# Altium schematic sheet EVB-NORA-B2_SCHEM_0003_USBhub_FTDI.SchDoc(EVB-NORA-B2_SCHEM_0003_USBhub_FTDI)
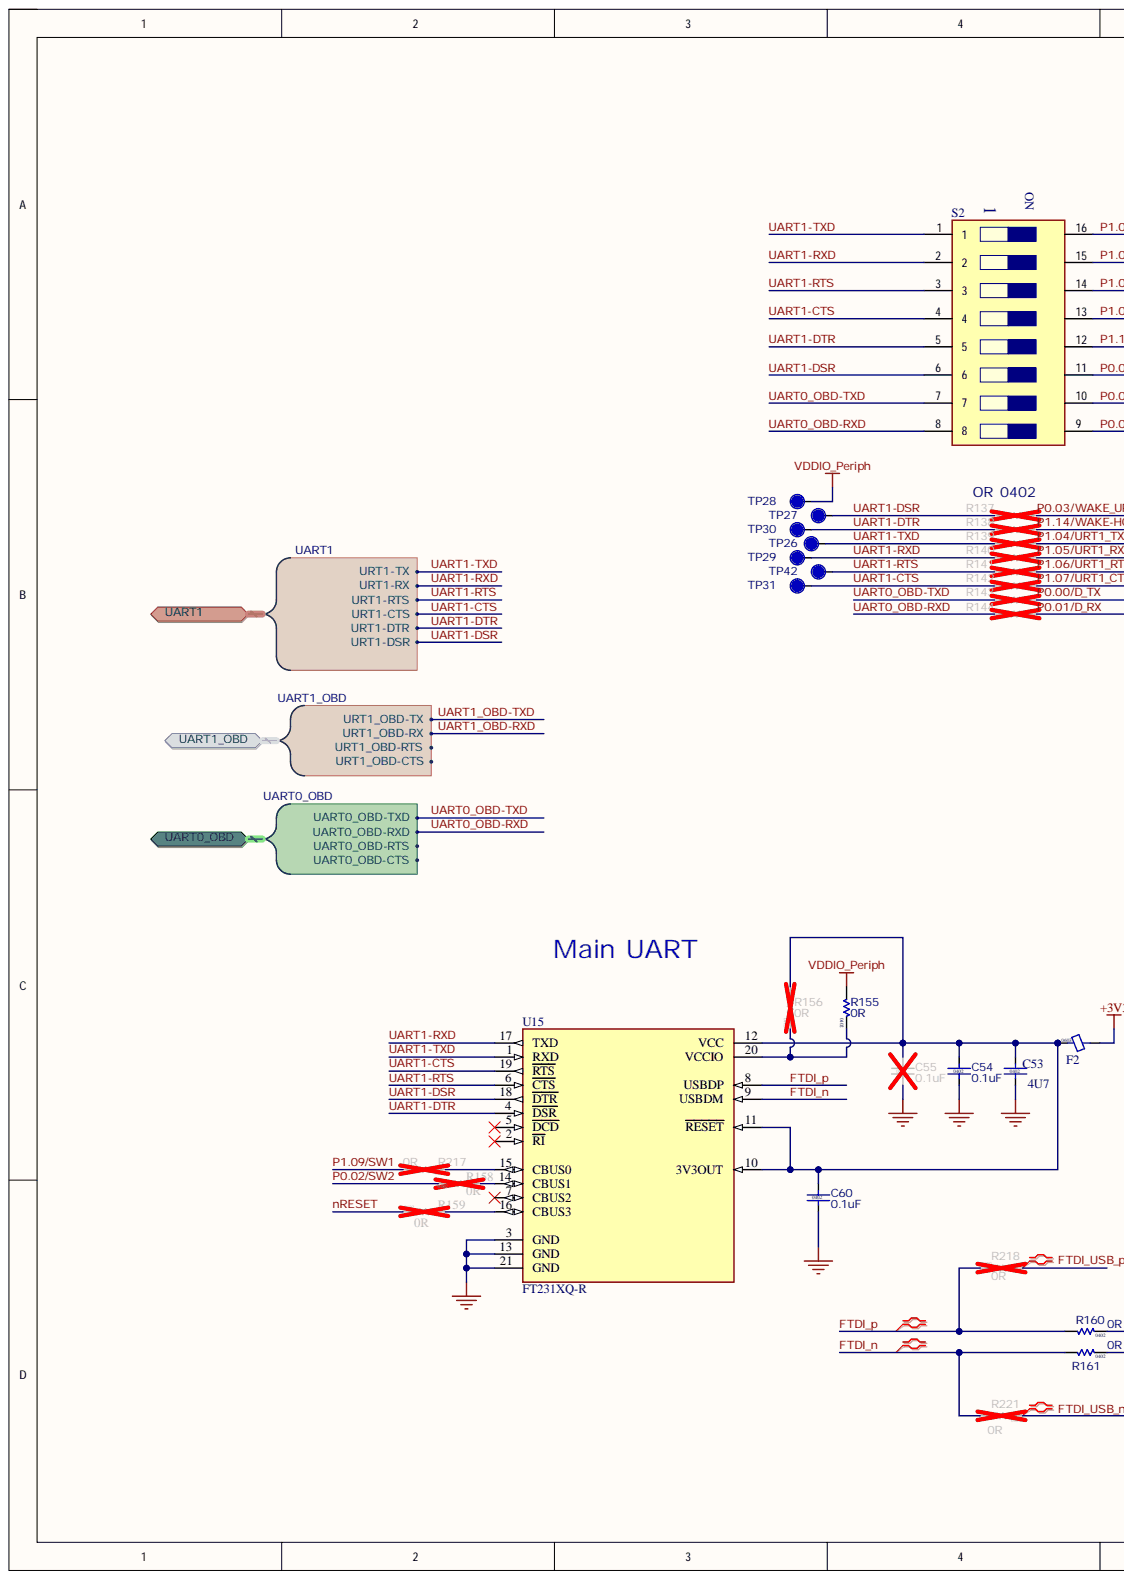
[diagram p0: EVB-NORA-B2_SCHEM_0003_USBhub_FTDI.SchDoc, left]
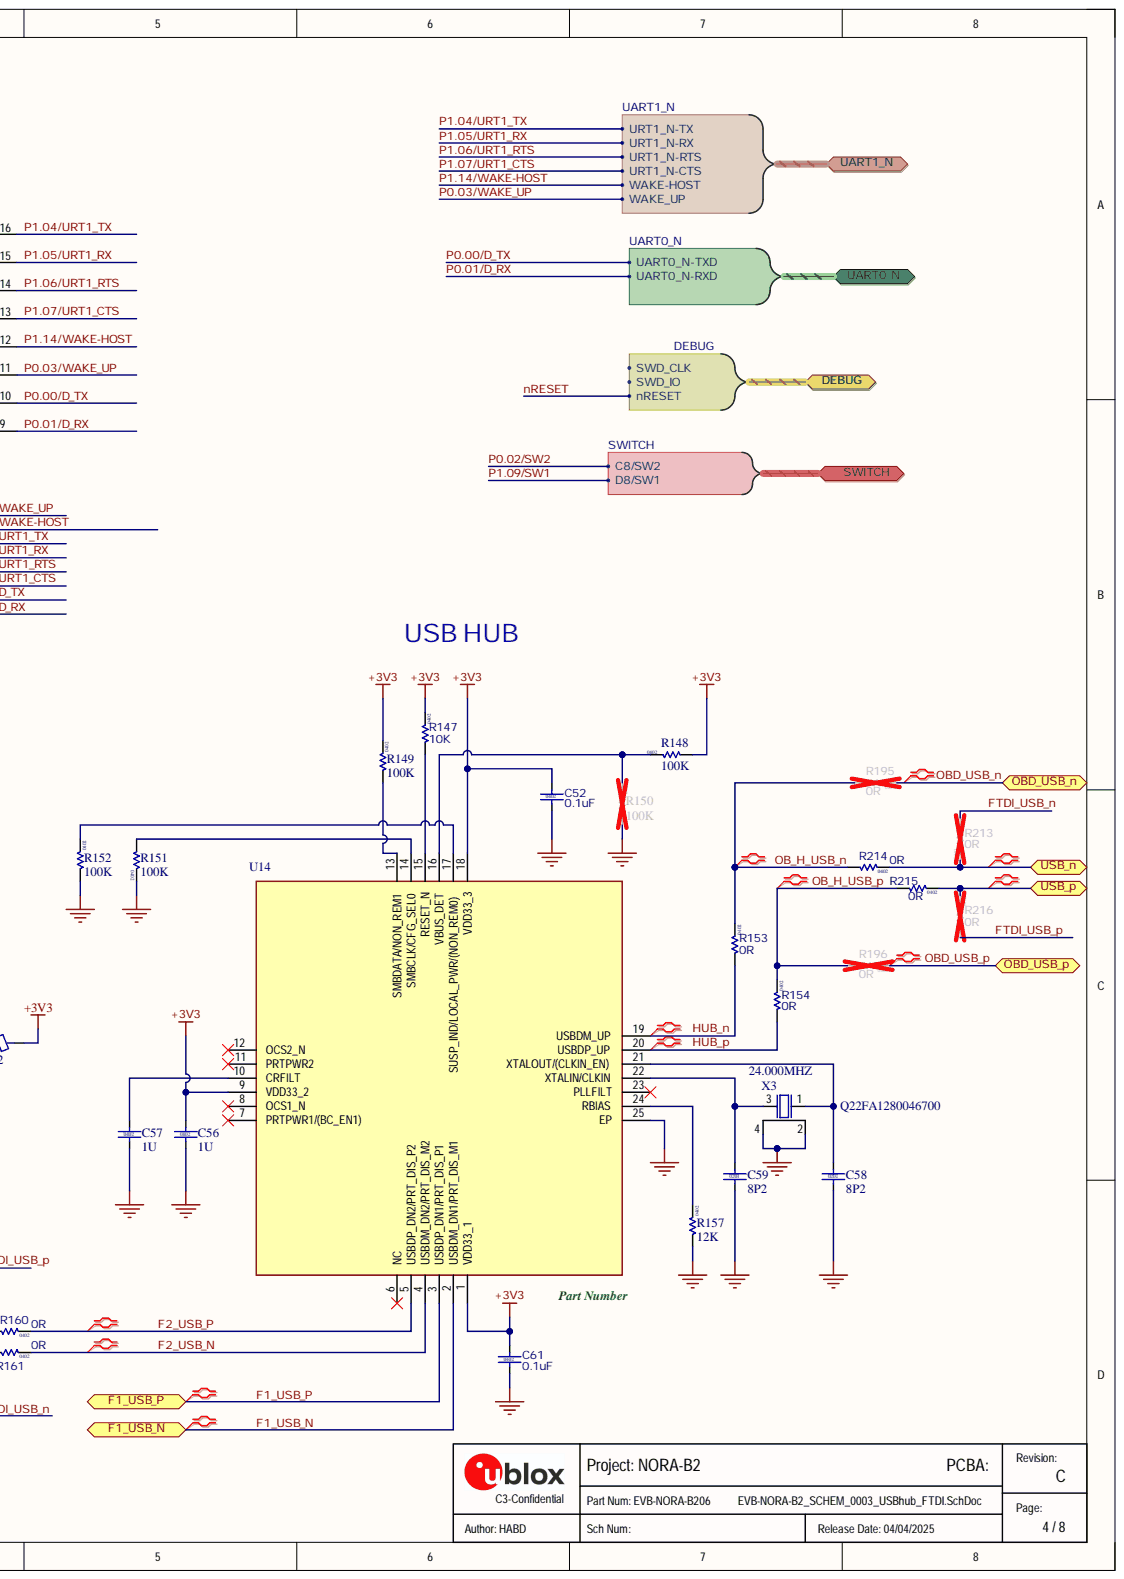
[diagram p1: EVB-NORA-B2_SCHEM_0003_USBhub_FTDI.SchDoc, right]

## Parts
C52  value 0.1uF  Size: 0402  [Cap 0402 HD]  (hidden comment: 120-00016)
C53  value 4U7  Size: 0402  [Cap 0402 HD]
C54  value 0.1uF  Size: 0402  [Cap 0402 HD]  (hidden comment: 120-000160.1uF)
C55  value 0.1uF  Size: 0402  [Cap 0402 HD]  (hidden comment: 120-000160.1uF)
C56  value 1U  Size: 0402  [Cap 0402 HD]  (hidden comment: UBXH01-0000248)
C57  value 1U  Size: 0402  [Cap 0402 HD]  (hidden comment: UBXH01-0000248)
C58  value 8P2  Size: 0201  [Cap 0201 HD]  (hidden comment: UBXH01-0013002)
C59  value 8P2  Size: 0201  [Cap 0201 HD]  (hidden comment: UBXH01-0013002)
C60  value 0.1uF  Size: 0402  [Cap 0402 HD]  (hidden comment: 120-000160.1uF)
C61  value 0.1uF  Size: 0402  [Cap 0402 HD]  (hidden comment: 120-00016)
F2  Size: 0603  [IND_0603_1750Lx950Wx1050H_600x800Pad_800G_FLX]
R137  Size: 0402  [RES 0402 HD]
R138  = "Part Number" + Value + DNP  [RES 0402 HD]
R139  = "Part Number" + Value + DNP  [RES 0402 HD]
R140  = "Part Number" + Value + DNP  [RES 0402 HD]
R141  = "Part Number" + Value + DNP  [RES 0402 HD]
R142  = "Part Number" + Value + DNP  [RES 0402 HD]
R143  = "Part Number" + Value + DNP  [RES 0402 HD]
R144  = "Part Number" + Value + DNP  [RES 0402 HD]
R147  value 10K  Size: 0402  [RES 0402 HD]  (hidden comment: UBXH18-0000065)
R148  value 100K  Size: 0402  [RES 0402 HD]  (hidden comment: UBXH18-0000005)
R149  value 100K  Size: 0402  [RES 0402 HD]  (hidden comment: UBXH18-0000005)
R150  value 100K  Size: 0402  [RES 0402 HD]
R151  value 100K  Size: 0402  [RES 0402 HD]  (hidden comment: UBXH18-0000005)
R152  value 100K  Size: 0402  [RES 0402 HD]  (hidden comment: UBXH18-0000005)
R153  value 0R  Size: 0402  [RES 0402 HD]
R154  value 0R  Size: 0402  [RES 0402 HD]
R155  value 0R  Size: 0402  [RES 0402 HD]
R156  value 0R  Size: 0402  [RES 0402 HD]
R157  value 12K  Size: 0402  [RES_0402_1100Lx600Wx400H_500x600Pad_400G_FLX]  (hidden comment: UBXH18-0000133)
R158  value 0R  Size: 0402  [RES_0402_1100Lx600Wx400H_500x600Pad_400G_FLX]
R159  value 0R  Size: 0402  [RES_0402_1100Lx600Wx400H_500x600Pad_400G_FLX]
R160  value 0R  Size: 0402  [RES 0402 HD]
R161  value 0R  Size: 0402  [RES 0402 HD]
R195  value 0R  Size: 0402  [RES 0402 HD]
R196  value 0R  Size: 0402  [RES 0402 HD]
R213  UBXH18-0003002  value 0R  Size: 0402  [RES 0402 HD]
R214  value 0R  Size: 0402  [RES 0402 HD]  (hidden comment: UBXH18-0003002)
R215  value 0R  Size: 0402  [RES 0402 HD]  (hidden comment: UBXH18-0003002)
R216  UBXH18-0003002  value 0R  Size: 0402  [RES 0402 HD]
R217  UBXH18-0003002  value 0R  Size: 0402  [RES 0402 HD]
R218  UBXH18-0003002  value 0R  Size: 0402  [RES 0402 HD]
R221  UBXH18-0003002  value 0R  Size: 0402  [RES 0402 HD]
S2  (no value)  [2188LPSTJ]
TP26  TP10R  [TP10R]  (value not drawn)  (no BOM)
TP27  TP10R  [TP10R]  (value not drawn)  (no BOM)
TP28  TP10R  [TP10R]  (value not drawn)  (no BOM)
TP29  TP10R  [TP10R]  (value not drawn)  (no BOM)
TP30  TP10R  [TP10R]  (value not drawn)  (no BOM)
TP31  TP10R  [TP10R]  (value not drawn)  (no BOM)
TP42  TP10R  [TP10R]  (value not drawn)  (no BOM)
U14  ="Part Number"  [QFN50P400X400X100-25N-D]
U15  value FT231XQ-R  Manufacturer P/N: FT231XQ-R  [QFN_5x5_FT231XQ]  (hidden comment: UBXH10-0000054)
X3  value 24.000MHZ  Manufacturer P/N: Q22FA1280046700  [XTAL_2016_HD]  (hidden comment: UBXH21-0000066)

## Ports
DEBUG, DEBUG.nRESET, DEBUG.SWD_CLK, DEBUG.SWD_IO, F1_USB_N, F1_USB_P, OBD_USB_n, OBD_USB_p, SWITCH, SWITCH.C8/SW2, SWITCH.D8/SW1, UART0_N, UART0_N.UART0_N-RXD, UART0_N.UART0_N-TXD, UART0_OBD, UART0_OBD.UART0_OBD-CTS, UART0_OBD.UART0_OBD-RTS, UART0_OBD.UART0_OBD-RXD, UART0_OBD.UART0_OBD-TXD, UART1, UART1.URT1-CTS, UART1.URT1-DSR, UART1.URT1-DTR, UART1.URT1-RTS, UART1.URT1-RX, UART1.URT1-TX, UART1_N, UART1_N.URT1_N-CTS, UART1_N.URT1_N-RTS, UART1_N.URT1_N-RX, UART1_N.URT1_N-TX, UART1_N.WAKE-HOST, UART1_N.WAKE_UP, UART1_OBD, UART1_OBD.URT1_OBD-CTS, UART1_OBD.URT1_OBD-RTS, UART1_OBD.URT1_OBD-RX, UART1_OBD.URT1_OBD-TX, USB_n, USB_p

## Nets
+3V3: C52.1 C56.1 C61.1 F2.1 R147.2 R148.2 R149.2 U14.1(VDD33_1) U14.9(VDD33_2) U14.18(VDD33_3)
GND: C52.2 C53.2 C54.2 C55.2 C56.2 C57.2 C58.2 C59.2 C60.2 C61.2 R150.1 R151.2 R152.1 R157.1 U14.25(EP) U15.3(GND) U15.13(GND) U15.21(GND) X3.2 X3.4
VDDIO_Periph: R155.1 TP28.1
F1_USB_N: U14.2(USBDM_DN1/PRT_DIS_M1) ; port F1_USB_N
F1_USB_P: U14.3(USBDP_DN1/PRT_DIS_P1) ; port F1_USB_P
F2_USB_N: R161.2 U14.4(USBDM_DN2/PRT_DIS_M2)
F2_USB_P: R160.2 U14.5(USBDP_DN2/PRT_DIS_P2)
FTDI_n: R161.1 R221.1 U15.9(USBDM)
FTDI_p: R160.1 R218.2 U15.8(USBDP)
FTDI_USB_n: R213.1 R221.2
FTDI_USB_p: R216.1 R218.1
HUB_n: R153.1 U14.19(USBDM_UP)
HUB_p: R154.1 U14.20(USBDP_UP)
nRESET: R159.2 ; port DEBUG
OB_H_USB_n: R153.2 R195.1 R214.1
OB_H_USB_p: R154.2 R196.1 R215.1
OBD_USB_n: R195.2 ; port OBD_USB_n
OBD_USB_p: R196.2 ; port OBD_USB_p
P0.00/D_TX: R143.2 S2.10 ; port UART0_N
P0.01/D_RX: R144.2 S2.9 ; port UART0_N
P0.02/SW2: R158.2 ; port SWITCH
P0.03/WAKE_UP: R137.2 S2.11 ; port UART1_N
P1.04/URT1_TX: R139.2 S2.16 ; port UART1_N
P1.05/URT1_RX: R140.2 S2.15 ; port UART1_N
P1.06/URT1_RTS: R141.2 S2.14 ; port UART1_N
P1.07/URT1_CTS: R142.2 S2.13 ; port UART1_N
P1.09/SW1: R217.1 ; port SWITCH
P1.14/WAKE-HOST: R138.2 S2.12 ; port UART1_N
UART0_OBD-RXD: R144.1 S2.8 ; port UART0_OBD
UART0_OBD-TXD: R143.1 S2.7 ; port UART0_OBD
UART1-CTS: R142.1 S2.4 TP31.1 U15.19(RTS) ; port UART1
UART1-DSR: R137.1 S2.6 TP27.1 U15.18(DTR) ; port UART1
UART1-DTR: R138.1 S2.5 TP30.1 U15.4(DSR) ; port UART1
UART1-RTS: R141.1 S2.3 TP42.1 U15.6(CTS) ; port UART1
UART1-RXD: R140.1 S2.2 TP29.1 U15.17(TXD) ; port UART1
UART1-TXD: R139.1 S2.1 TP26.1 U15.1(RXD) ; port UART1
USB_n: R213.2 R214.2 ; port USB_n
USB_p: R215.2 R216.2 ; port USB_p
NetC53_1: C53.1 C54.1 C55.1 C60.1 F2.2 R156.1 U15.10(3V3OUT) U15.11(RESET) U15.12(VCC)
NetC57_1: C57.1 U14.10(CRFILT)
NetC58_1: C58.1 U14.21(XTALOUT/(CLKIN_EN)) X3.1
NetC59_1: C59.1 U14.22(XTALIN/CLKIN) X3.3
NetR147_1: R147.1 U14.15(RESET_N)
NetR148_1: R148.1 R150.2 U14.16(VBUS_DET)
NetR149_1: R149.1 U14.13(SMBDATA/NON_REM1)
NetR151_1: R151.1 U14.14(SMBCLK/CFG_SEL0)
NetR152_2: R152.2 U14.17(SUSP_IND/LOCAL_PWR/(NON_REM0))
NetR155_2: R155.2 R156.2 U15.20(VCCIO)
NetR157_2: R157.2 U14.24(RBIAS)
NetR158_1: R158.1 U15.14(CBUS1)
NetR159_1: R159.1 U15.16(CBUS3)
NetR217_2: R217.2 U15.15(CBUS0)
N00018: ; port DEBUG
N00020: ; port DEBUG
N00024: ; port UART0_OBD
N00025: ; port UART0_OBD
N00026: ; port UART1_OBD
N00027: ; port UART1_OBD
UART1_OBD-RXD: ; port UART1_OBD
UART1_OBD-TXD: ; port UART1_OBD

Not connected: U14 6(NC) 7(PRTPWR1/(BC_EN1)) 8(OCS1_N) 11(PRTPWR2) 12(OCS2_N) 23(PLLFILT); U15 2(RI) 5(DCD) 7(CBUS2)

## Library data of the parts
C52 C54 C55 C60 C61 = 120-00016: Cap, Ceramic 0.1uF 10V 10% X5R 0402; Manufacturer: Murata Electronics North America; Manufacturer P/N: GRM155R61A104KA01J; Part Name: CAP CER 0.1UF 10V 10% X5R 0402; Component Mounting Type: SMT; Database Table Name: Ceramic Capacitor; Item_Phase: Production; Tolerance: +/- 10%; Use in new designs: Yes; Arena Link: https://app.bom.com/a/GYHPV2L2L43KRMBK?v=spec.summary; Component Kind: Standard; Height: 0.55mm; Manufacturer 2: Yageo; Manufacturer P/N 2: CC0402KRX5R6BB104; Part Number: 120-00016; Part_Type: Ceramic Capacitor; Pins: 2; Revision: 0A; Signal Integrity: Cap Semi; Simulation: CAP; Supplier 1: Digi-Key; Supplier 2: Digi-Key; Supplier Part Number 1: 490-6297-1-ND; Supplier Part Number 2: 311-1336-1-ND; Temperature Coefficient: X5R; Voltage: 10V; Library Name: Arena_Parts_DB_Lib.DbLib
C53 = UBXH01-0000243: CAP CER X5R 0402 4U7 20% 10V; Manufacturer: Murata Manufacturing Company, Ltd.; Manufacturer P/N: GRM155R61A475MEAA; Database Table Name: Passive - Capacitor; Editor: martin.pilik; Env: GREEN; Mfg P/N Released: Yes; Mfg P/N Split: 100; Mfg P/N Status: Active; Perferred: PREF; Permitted Use: Volume Products; Released by: fabrice.bret-dibat; Comment: ="Part Number" + Value + DNP; Component Kind: Standard; Library Name: UBX_Parts_DB_Lib.DbLib; Max Operating Temp: 85°C; Max Voltage: 10V; Mfg P/N Datasheet: https://wiki.u-blox.com/bin/view/Generic/Docs/DocumentSummary?index=24302; Min Operating Temp: -40°C; Part Number: UBXH01-0000243; Part Type: Capacitor; RefDes: C; Release Data: 2021-05-10 12:57:47.325652; Signal Integrity: Cap Semi; Simulation: CAP; Tolerance: 20%; UBX Wiki: https://wiki.u-blox.com/bin/view/Operations/PartDetails?index=24303
C56 C57 = UBXH01-0000248: CAP CER X5R 0402 1U 10% 10V; Manufacturer: Murata Manufacturing Company, Ltd.; Manufacturer P/N: GRM155R61C105KA12; Database Table Name: Passive - Capacitor; Editor: michael.hein; Env: ROHS; Mfg P/N Released: Yes; Mfg P/N Split: 100; Mfg P/N Status: Active; Perferred: PREF; Permitted Use: Volume Products; Released by: igor.shevchenko; Component Kind: Standard; Library Name: UBX_Parts_DB_Lib.DbLib; Manufacturer 2: TDK Corporation; Manufacturer 3: Samsung Electro-Mechanics; Manufacturer P/N 2: C1005X5R1E105K050BC; Manufacturer P/N 3: CL05A105KA5NQNC; Max Operating Temp: 85°C; Max Voltage: 10V; Mfg P/N Datasheet: https://wiki.u-blox.com/bin/view/Generic/Docs/DocumentSummary?index=29581; Mfg P/N Datasheet 2: https://wiki.u-blox.com/bin/view/Generic/Docs/DocumentSummary?index=28058; Mfg P/N Datasheet 3: https://wiki.u-blox.com/bin/view/Generic/Docs/DocumentSummary?index=28056; Mfg P/N Released 2: Yes; Mfg P/N Released 3: Yes; Mfg P/N Split 2: 0; Mfg P/N Split 3: 0; Mfg P/N Status 2: Active; Mfg P/N Status 3: Active; Min Operating Temp: -40°C; Part Number: UBXH01-0000248; Part Type: Capacitor; RefDes: C; Release Data: 2016-03-16T11:26:35; Signal Integrity: Cap Semi; Simulation: CAP; Tolerance: 10%; UBX Wiki: https://wiki.u-blox.com/bin/view/Operations/PartDetails?index=24378
C58 C59 = UBXH01-0013002: CAP CER C0G 0201 8P2 +/-0.5P 25V; Manufacturer: Murata Manufacturing Company, Ltd.; Manufacturer P/N: GRM0335C1E8R2DA01; Database Table Name: Passive - Capacitor; Editor: roland.rust; Env: ROHS; Mfg P/N Released: Yes; Mfg P/N Split: 100; Mfg P/N Status: Active; Perferred: PREF; Permitted Use: Volume Products; Component Kind: Standard; Library Name: UBX_Parts_DB_Lib.DbLib; Manufacturer 2: Murata Manufacturing Company, Ltd.; Manufacturer P/N 2: GRM0335C1E8R2D; Max Voltage: 25V; Mfg P/N Released 2: Obsolete; Mfg P/N Split 2: 0; Mfg P/N Status 2: Active; Part Number: UBXH01-0013002; Part Type: Capacitor; RefDes: C; Release Data: 2009-03-23T10:34:14; Signal Integrity: Cap Semi; Simulation: CAP; Tolerance: +/-0.5P; UBX Wiki: https://wiki.u-blox.com/bin/view/Operations/PartDetails?index=5090; Released by: roland.rust
F2 = UBXH08-0000001: FERRITE BEAD 0603 600 OHM AT 100MHZ 600OHM 500mA; Manufacturer: TDK Corporation; Manufacturer P/N: MMZ1608B601C; Database Table Name: Passive - Ferrite; Editor: daniel.hurni; Env: ROHS; Mfg P/N Released: Yes; Mfg P/N Split: 100; Mfg P/N Status: Active; Perferred: PREF; Permitted Use: Support Products; Released by: martin.frauchiger; Comment: = "Part Number" + DNP; Component Kind: Standard; Library Name: UBX_Parts_DB_Lib.DbLib; Max Current: 500mA; Max Operating Temp: 125°C; Mfg P/N Datasheet: https://wiki.u-blox.com/bin/view/Generic/Docs/DocumentSummary?index=7941; Min Operating Temp: -40°C; Part Number: UBXH08-0000001; Part Type: Ferrite; RefDes: FB; Release Data: 2009-12-02T10:18:36; UBX Wiki: https://wiki.u-blox.com/bin/view/Operations/PartDetails?index=7960; Value: 600OHM
R137-R144 R153 R154 R158-R161 R195 R196 R213-R216 R218 R221 = UBXH18-0003002: RES THICK FILM CHIP 0402 0R 0 100mW; Manufacturer: KOA; Manufacturer P/N: RK73Z1ETTD; Database Table Name: Passive - Resistor; Editor: charlotte.biber; Env: ROHS; Mfg P/N Released: Yes; Mfg P/N Split: 100; Mfg P/N Status: NRND; Perferred: PREF; Permitted Use: Volume Products; Released by: peter.mueller; Tolerance: 0; Component Kind: Standard; Library Name: UBX_Parts_DB_Lib.DbLib; Manufacturer 2: YAGEO; Manufacturer P/N 2: 2322_705_91001_L; Max Power: 100mW; Mfg P/N Released 2: Yes; Mfg P/N Split 2: 0; Part Number: UBXH18-0003002; Part Type: Resistor; RefDes: R; Signal Integrity: Res; Simulation: RESISTOR; UBX Wiki: https://wiki.u-blox.com/bin/view/Operations/PartDetails?index=6611
  R137: Comment: = "Part Number" + Value + DNP; Mfg P/N Status 2: NRND; Release Data: 2012-06-05 09:49:55.978616; Value: 0R
  R138-R144: Mfg P/N Status 2: NRND; Release Data: 2012-06-05 09:49:55.978616; Size: 0402; Value: 0R
  R153 R154 R160 R161 R195 R196: Comment: = "Part Number" + Value + DNP; Mfg P/N Status 2: NRND; Release Data: 2012-06-05 09:49:55.978616
  R158 R159: Case/Package: 0x0y; Comment: = "Part Number" + Value + DNP; Mfg P/N Status 2: Obsolete; Release Data: 2012-06-05T09:49:55
  R213-R216 R218 R221: Mfg P/N Status 2: NRND; Release Data: 2012-06-05 09:49:55.978616
R147 = UBXH18-0000065: RES THICK FILM CHIP 0402 10K 1% 63mW; Manufacturer: KOA; Manufacturer P/N: RK73H1ETTP1002F; Database Table Name: Passive - Resistor; Editor: erian.bezhani; Env: ROHS; Mfg P/N Released: Yes; Mfg P/N Split: 100; Mfg P/N Status: Active; Perferred: PREF; Permitted Use: Volume Products; Released by: massimo.vlacci; Component Kind: Standard; Library Name: UBX_Parts_DB_Lib.DbLib; Max Power: 63mW; Mfg P/N Datasheet: https://wiki.u-blox.com/bin/view/Generic/Docs/DocumentSummary?index=8222; Part Number: UBXH18-0000065; Part Type: Resistor; RefDes: R; Release Data: 2012-06-08 18:02:21.521517; Signal Integrity: Res; Simulation: RESISTOR; Tolerance: 1%; UBX Wiki: https://wiki.u-blox.com/bin/view/Operations/PartDetails?index=16837
R148-R152 = UBXH18-0000005: RES THICK FILM CHIP 0402 100K 1% 63mW; Manufacturer: KOA; Manufacturer P/N: RK73H1ETTP1003F; Database Table Name: Passive - Resistor; Editor: dario.antonaz; Env: ROHS; Mfg P/N Released: Yes; Mfg P/N Split: 100; Mfg P/N Status: Active; Permitted Use: Volume Products; Released by: sandro.sestan; Component Kind: Standard; Library Name: UBX_Parts_DB_Lib.DbLib; Manufacturer 2: ROHM; Manufacturer P/N 2: MCR01MZPF1003; Max Power: 63mW; Mfg P/N Datasheet: https://wiki.u-blox.com/bin/view/Generic/Docs/DocumentSummary?index=8222; Mfg P/N Datasheet 2: https://wiki.u-blox.com/bin/view/Generic/Docs/DocumentSummary?index=8186; Mfg P/N Released 2: Yes; Mfg P/N Split 2: 0; Mfg P/N Status 2: Obsolete; Part Number: UBXH18-0000005; Part Type: Resistor; Perferred: N/A; RefDes: R; Release Data: 2009-11-30T11:05:22; Signal Integrity: Res; Simulation: RESISTOR; Tolerance: 1%; UBX Wiki: https://wiki.u-blox.com/bin/view/Operations/PartDetails?index=8114
  R150: Comment: = "Part Number" + Value + DNP
R155 R156 R217 = UBXH18-0003002: RES THICK FILM CHIP 0402 0R 0 100mW; Manufacturer: KOA; Manufacturer P/N: RK73Z1ETTP; Database Table Name: Passive - Resistor; Editor: charlotte.biber; Env: ROHS; Mfg P/N Released: Yes; Mfg P/N Split: 100; Mfg P/N Status: NRND; Perferred: PREF; Permitted Use: Volume Products; Released by: peter.mueller; Tolerance: 0; Component Kind: Standard; Library Name: UBX_Parts_DB_Lib.DbLib; Manufacturer 2: YAGEO; Manufacturer P/N 2: 2322_705_91001_L; Max Power: 100mW; Mfg P/N Released 2: Yes; Mfg P/N Split 2: 0; Mfg P/N Status 2: NRND; Part Number: UBXH18-0003002; Part Type: Resistor; RefDes: R; Release Data: 2012-06-05 09:49:55.978616; Signal Integrity: Res; Simulation: RESISTOR; UBX Wiki: https://wiki.u-blox.com/bin/view/Operations/PartDetails?index=6611
  R155 R156: Comment: = "Part Number" + Value + DNP
R157 = UBXH18-0000133: RES THICK FILM CHIP 0402 12K 1% 62.5mW; Manufacturer: YAGEO; Manufacturer P/N: RT0402FRE0712KL; Database Table Name: Passive - Resistor; Editor: pierpaolo.arban; Env: ROHS; Mfg P/N Released: Yes; Mfg P/N Split: 100; Mfg P/N Status: Active; Perferred: PREF; Permitted Use: Volume Products; Released by: dario.antonaz; Component Kind: Standard; Library Name: UBX_Parts_DB_Lib.DbLib; Max Operating Temp: 155°C; Max Power: 62.5mW; Mfg P/N Datasheet: https://wiki.u-blox.com/bin/view/Generic/Docs/DocumentSummary?index=19276; Min Operating Temp: -55°C; Part Number: UBXH18-0000133; Part Type: Resistor; RefDes: R; Release Data: 2019-11-07T09:33:25; Signal Integrity: Res; Simulation: RESISTOR; Tolerance: 1%; UBX Wiki: https://wiki.u-blox.com/bin/view/Operations/PartDetails?index=20511
S2 = 218-8LPSTJ: Switch; Manufacturer_Name: CTS; Arrow Part Number: 218-8LPSTJ; Arrow Price/Stock: https://www.arrow.com/en/products/218-8lpstj/cts; Component Kind: Standard; Datasheet Link: https://www.ctscorp.com/wp-content/uploads/218.pdf; Height: 2.7mm; Library Name: SamacSys.SchLib; Manufacturer_Part_Number: 218-8LPSTJ; Mouser Part Number: 774-2188LPSTJ; Mouser Price/Stock: https://www.mouser.co.uk/ProductDetail/CTS-Electronic-Components/218-8LPSTJ?qs=hDoPxpGr7lfoBDyeqUpd9g%3D%3D
TP26-TP31 TP42 = TP10R: Testpoint, Round 1.0mm Dia; Added By: Jeremy D; Database Table Name: Testpoints & Pads; Added Date: 2/1/2015; Checked Date: 2/5/2015; DNP: DNP; Height: 0; Part Number: TP10R; Size: 1.0mm; Checked By: Paul B; Library Name: LEGACY_Rigado_AltiumLib.DbLib
U14 = USB2422-I_MJ: Integrated Circuit; Manufacturer_Name: Microchip; Manufacturer_Part_Number: USB2422-I/MJ; Arrow Part Number: USB2422-I/MJ; Arrow Price/Stock: https://www.arrow.com/en/products/usb2422-imj/microchip-technology?region=nac; Component Kind: Standard; Datasheet Link: https://datasheet.datasheetarchive.com/originals/distributors/DKDS-15/280029.pdf; Height: 1mm; Mouser Part Number: 579-USB2422-I/MJ; Mouser Price/Stock: https://www.mouser.co.uk/ProductDetail/Microchip-Technology-Atmel/USB2422-I-MJ?qs=eTPcRhULBzzm1uvzgv8YJg%3D%3D
U15 = UBXH10-0000054: FTDI USB TO SERIAL FULL UART INTERFACE QFN20; Manufacturer: FTDI Future Technology Devices International; Database Table Name: IC - Interface; Editor: adam.johansson; Env: ROHS; Mfg P/N Released: No; Mfg P/N Split: 100; Mfg P/N Status: Active; Perferred: PREF; Permitted Use: Support Products; Component Kind: Standard; Library Name: UBX_Parts_DB_Lib.DbLib; Max Operating Temp: 85°C; Mfg P/N Datasheet: https://wiki.u-blox.com/bin/view/Generic/Docs/DocumentSummary?index=33707; Min Operating Temp: -40°C; Part Number: UBXH10-0000054; Part Type: Interface; RefDes: U; UBX Wiki: https://wiki.u-blox.com/bin/view/Operations/PartDetails?index=27258
X3 = UBXH21-0000066: CRYSTAL CL=8PF EPSON 2.0X1.6MM 24.000MHZ 30PPM; Manufacturer: Seiko Epson Corporation; Critical: Yes; Database Table Name: Passive - Crystal; Editor: adam.johansson; Env: ROHS; Mfg P/N Split: 100; Mfg P/N Status: Active; Perferred: PREF; Permitted Use: Volume Products; Released by: olof.viklund; Component Kind: Standard; Library Name: UBX_Parts_DB_Lib.DbLib; Manufacturer 2: Seiko Epson Corporation; Manufacturer P/N 2: Q22FA1280039800; Max Operating Temp: 85°C; Mfg P/N Datasheet: https://wiki.u-blox.com/bin/view/Generic/Docs/DocumentSummary?index=23017; Mfg P/N Datasheet 2: https://wiki.u-blox.com/bin/view/Generic/Docs/DocumentSummary?index=21965; Mfg P/N Released: Yes; Mfg P/N Released 2: Obsolete; Mfg P/N Split 2: 0; Mfg P/N Status 2: Obsolete; Min Operating Temp: -40°C; Part Number: UBXH21-0000066; Part Type: Crystal; RefDes: Y; Release Data: 2015-11-11T10:35:48; Tolerance: 30PPM; UBX Wiki: https://wiki.u-blox.com/bin/view/Operations/PartDetails?index=21963
